# Altium schematic sheet I-LED.SchDoc(I-LED)
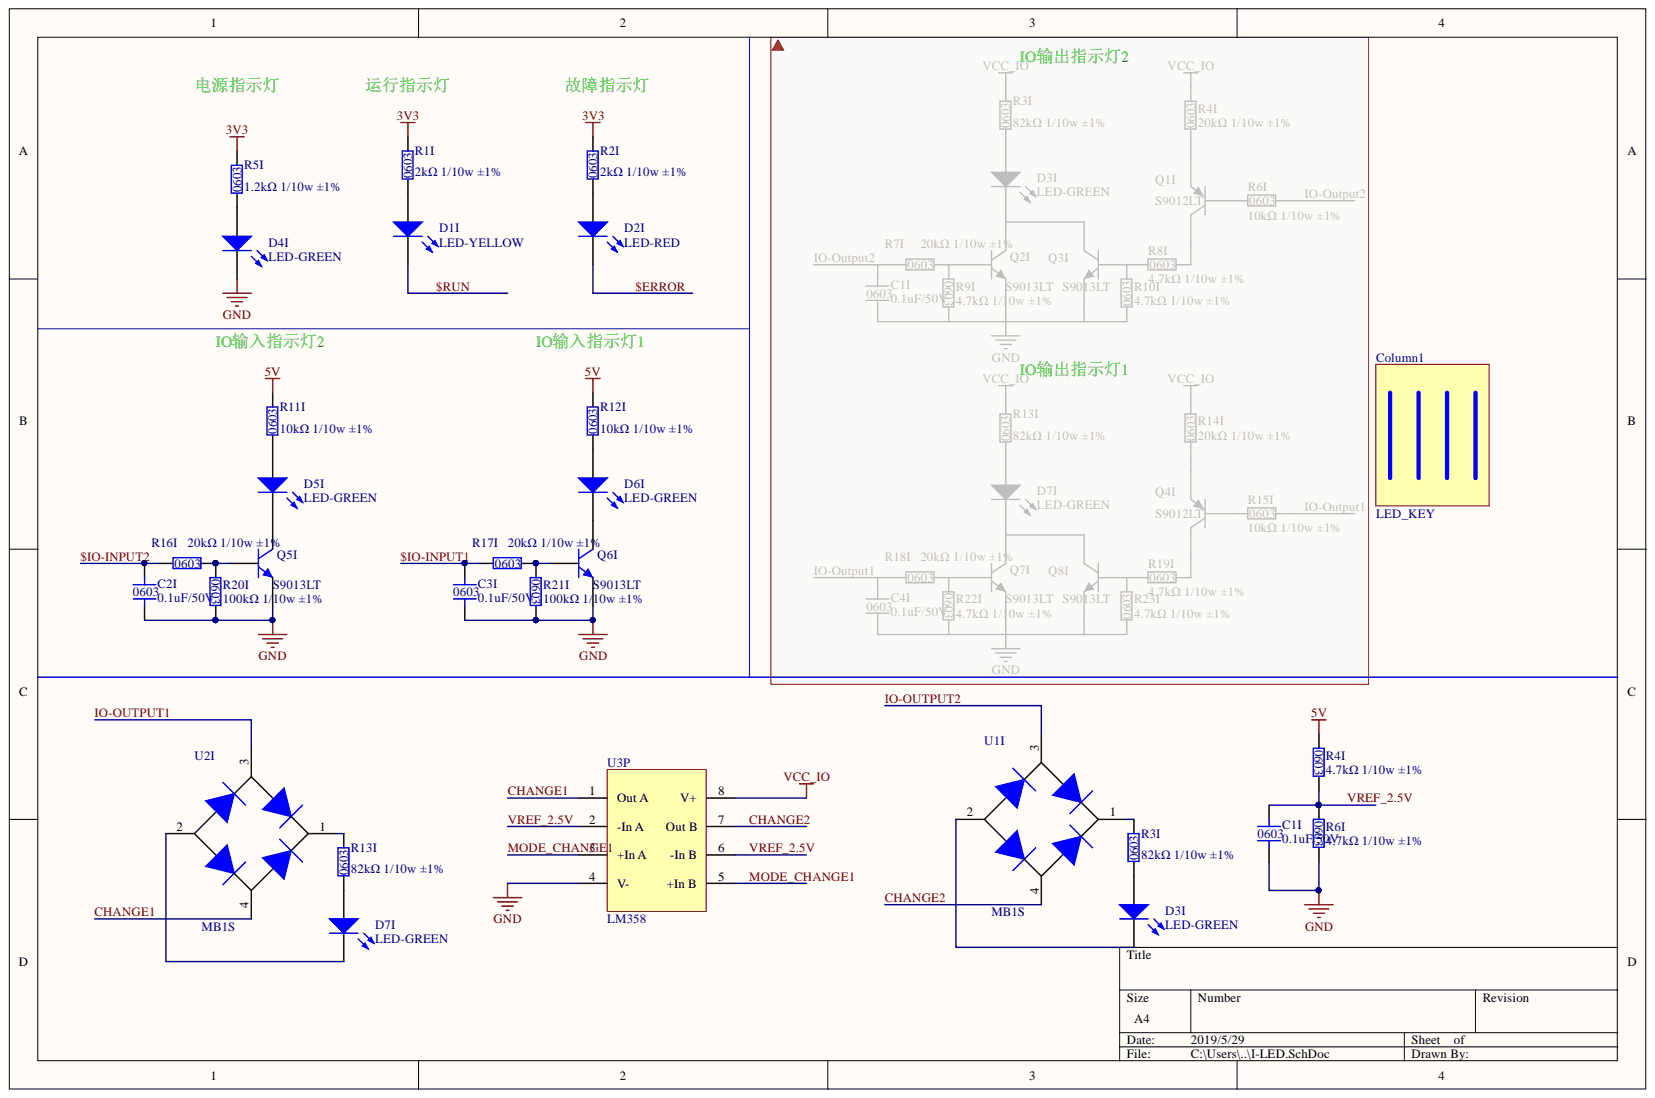
[diagram p0: I-LED.SchDoc]

## Parts
C1I  0.1uF/50V  [C-0603]
C2I  0.1uF/50V  [C-0603]
C3I  0.1uF/50V  [C-0603]
Column1  LED_KEY  [LPE4-5-19]
D1I  LED-YELLOW  [3MM灯90°弯脚]
D2I  LED-RED  [3MM灯90°弯脚]
D3I  LED-GREEN  [LED-0603]
D4I  LED-GREEN  [3MM灯90°弯脚]
D5I  LED-GREEN  [LED-0603]
D6I  LED-GREEN  [LED-0603]
D7I  LED-GREEN  [LED-0603]
Q5I  S9013LT  [SOT-23]
Q6I  S9013LT  [SOT-23]
R1I  2kΩ 1/10w ±1%  [R-0603]
R2I  2kΩ 1/10w ±1%  [R-0603]
R3I  82kΩ 1/10w ±1%  [R-0603]
R4I  4.7kΩ 1/10w ±1%  [R-0603]
R5I  1.2kΩ 1/10w ±1%  [R-0603]
R6I  4.7kΩ 1/10w ±1%  [R-0603]
R11I  10kΩ 1/10w ±1%  [R-0603]
R12I  10kΩ 1/10w ±1%  [R-0603]
R13I  82kΩ 1/10w ±1%  [R-0603]
R16I  20kΩ 1/10w ±1%  [R-0603]
R17I  20kΩ 1/10w ±1%  [R-0603]
R20I  100kΩ 1/10w ±1%  [R-0603]
R21I  100kΩ 1/10w ±1%  [R-0603]
U1I  MB1S  [SOIC-4]
U2I  MB1S  [SOIC-4]
U3P  LM358  [SOP-8]

## Nets
3V3: R1I.2 R2I.2 R5I.2
5V: R4I.1 R11I.2 R12I.2
GND: C1I.1 C2I.1 C3I.1 D4I.2 Q5I.2 Q6I.2 R6I.2 R20I.2 R21I.2 U3P.4(V-)
VCC_IO: U3P.8(V+)
$ERROR: D2I.2
$IO-INPUT1: C3I.2 R17I.1
$IO-INPUT2: C2I.2 R16I.1
$RUN: D1I.2
CHANGE1: U2I.4 U3P.1(Out_A)
CHANGE2: U1I.4 U3P.7(Out_B)
IO-OUTPUT1: U2I.3
IO-OUTPUT2: U1I.3
MODE_CHANGE1: U3P.3(+In_A) U3P.5(+In_B)
VREF_2.5V: C1I.2 R4I.2 R6I.1 U3P.2(-In_A) U3P.6(-In_B)
NetD1I_1: D1I.1 R1I.1
NetD2I_1: D2I.1 R2I.1
NetD3I_1: D3I.1 R3I.1
NetD3I_2: D3I.2 U1I.2
NetD4I_1: D4I.1 R5I.1
NetD5I_1: D5I.1 R11I.1
NetD5I_2: D5I.2 Q5I.3
NetD6I_1: D6I.1 R12I.1
NetD6I_2: D6I.2 Q6I.3
NetD7I_1: D7I.1 R13I.1
NetD7I_2: D7I.2 U2I.2
NetQ5I_1: Q5I.1 R16I.2 R20I.1
NetQ6I_1: Q6I.1 R17I.2 R21I.1
NetR3I_2: R3I.2 U1I.1
NetR13I_2: R13I.2 U2I.1

## Library data of the parts
C1I C2I C3I = 10uF/50V: Component Kind: Standard; Library Name: dahuan.SchLib
D1I D2I D3I D4I D5I D6I D7I = LED3: Typical BLUE SiC LED; Component Kind: Standard; DatasheetVersion: 16-Apr-2002; LatestRevisionDate: 17-Dec-2003; LatestRevisionNote: PCB Footprint replaced.; Library Name: Miscellaneous Devices.IntLib; PackageDescription: SM LED; 2 C-Bend Leads; Body 3.5 x 2.8 x 1.9 mm, inc leads (LxWxH typ); PackageReference: 3.5X2.8X1.9; PackageVersion: 16-Apr-2002; Published: 8-Jun-2000; Signal Integrity: LED; Simulation: LED3; Publisher: Altium Limited
Q5I Q6I = NPN: Component Kind: Standard; Library Name: dahuan.SchLib
R3I R4I R5I R6I R11I R12I R13I R16I R17I R20I R21I = 2kΩ 1/10w ±1%: Component Kind: Standard; Library Name: dahuan.SchLib
U1I U2I = Bridge rectifier: Component Kind: Standard; Library Name: dahuan.SchLib
U3P = OPA2134PA: Component Kind: Standard; Library Name: dahuan.SchLib
Column1 = LED_KEY: Component Kind: Standard; Library Name: dahuan.SchLib
R1I R2I = 2kΩ 1/10w ±1%: Component Kind: Standard; Library Name: dahuan.SchLib
